# Altium schematic sheet DIY_DAP_PCB_MicroSD.SchDoc(DIY_DAP_PCB_MicroSD)
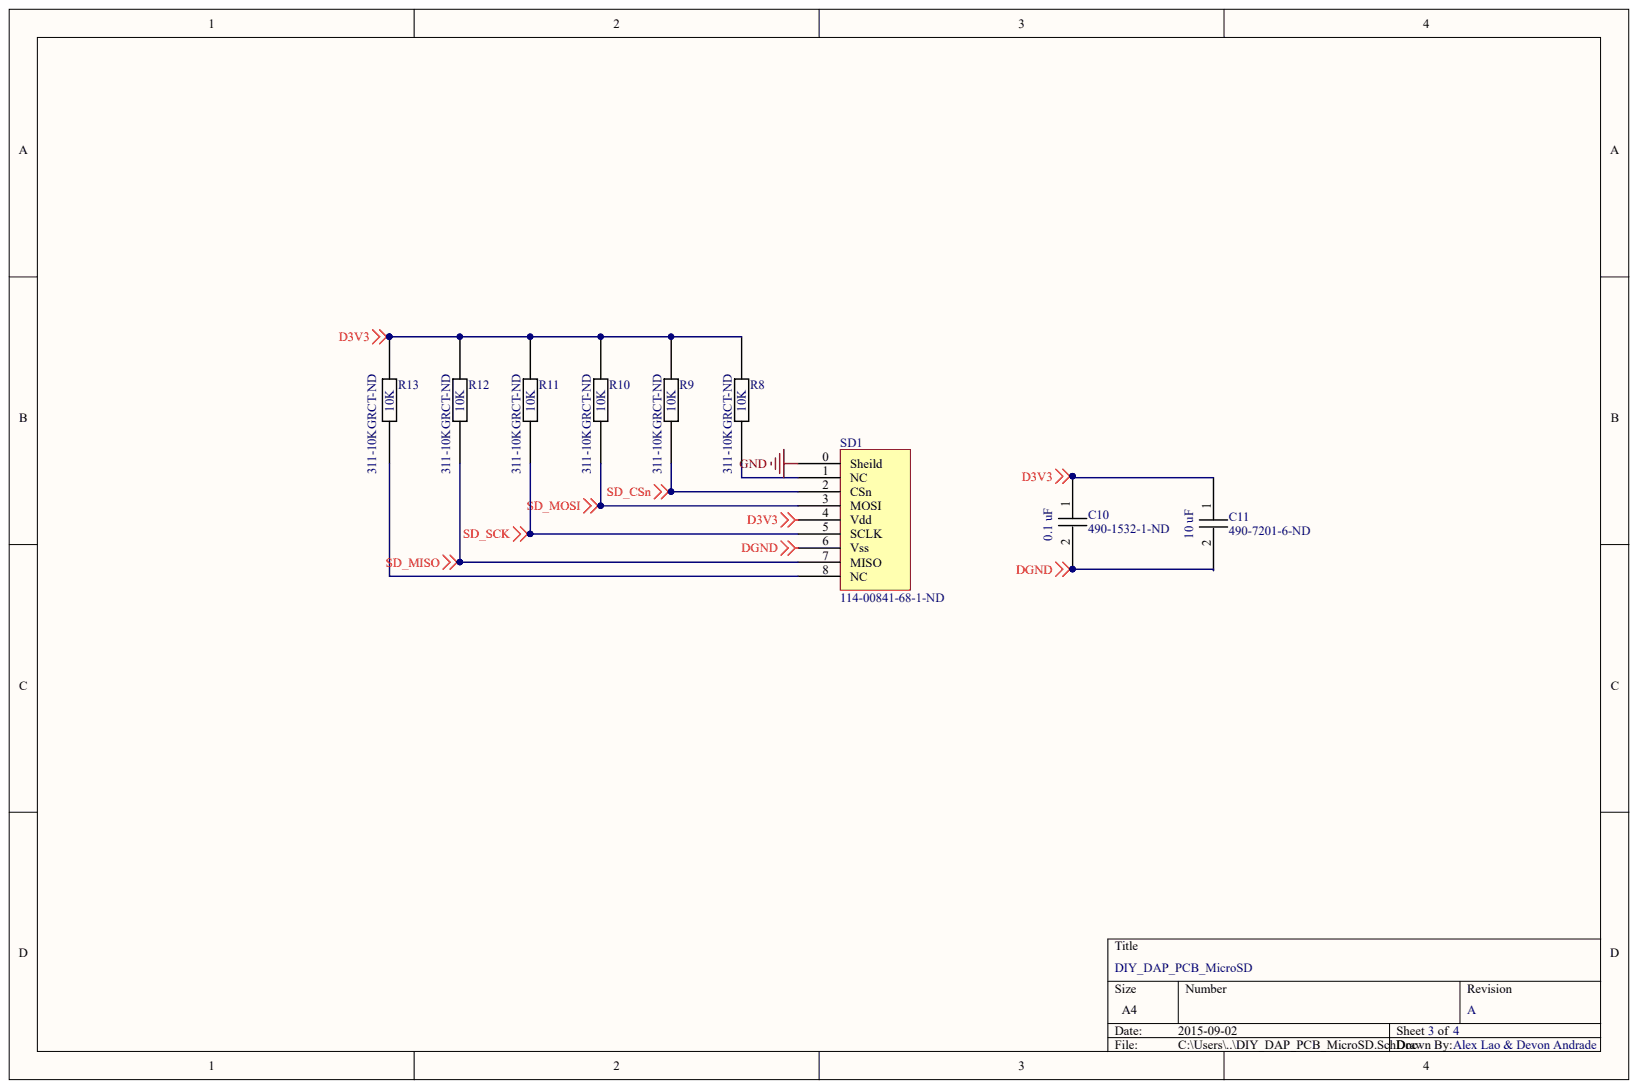
[diagram p0: DIY_DAP_PCB_MicroSD.SchDoc]

## Parts
C10  490-1532-1-ND
C11  490-7201-6-ND
R8  311-10KGRCT-ND
R9  311-10KGRCT-ND
R10  311-10KGRCT-ND
R11  311-10KGRCT-ND
R12  311-10KGRCT-ND
R13  311-10KGRCT-ND
SD1  114-00841-68-1-ND

## Nets
D3V3: C10.1 C11.1 R8.1 R9.1 R10.1 R11.1 R12.1 R13.1 SD1.4(Vdd)
DGND: C10.2 C11.2 SD1.6(Vss)
GND: SD1.0(Sheild)
SD_CSN: R9.2 SD1.2(CSn)
SD_MISO: R12.2 SD1.7(MISO)
SD_MOSI: R10.2 SD1.3(MOSI)
SD_SCK: R11.2 SD1.5(SCLK)
NetR8_2: R8.2 SD1.1(NC)
NetR13_2: R13.2 SD1.8(NC)

## Library data of the parts
C10 C11 R8-R13 SD1 = (no symbol name): Supplier 1: Digi-Key
